# Altium schematic sheet PulserSampler.SchDoc(PulserSampler)
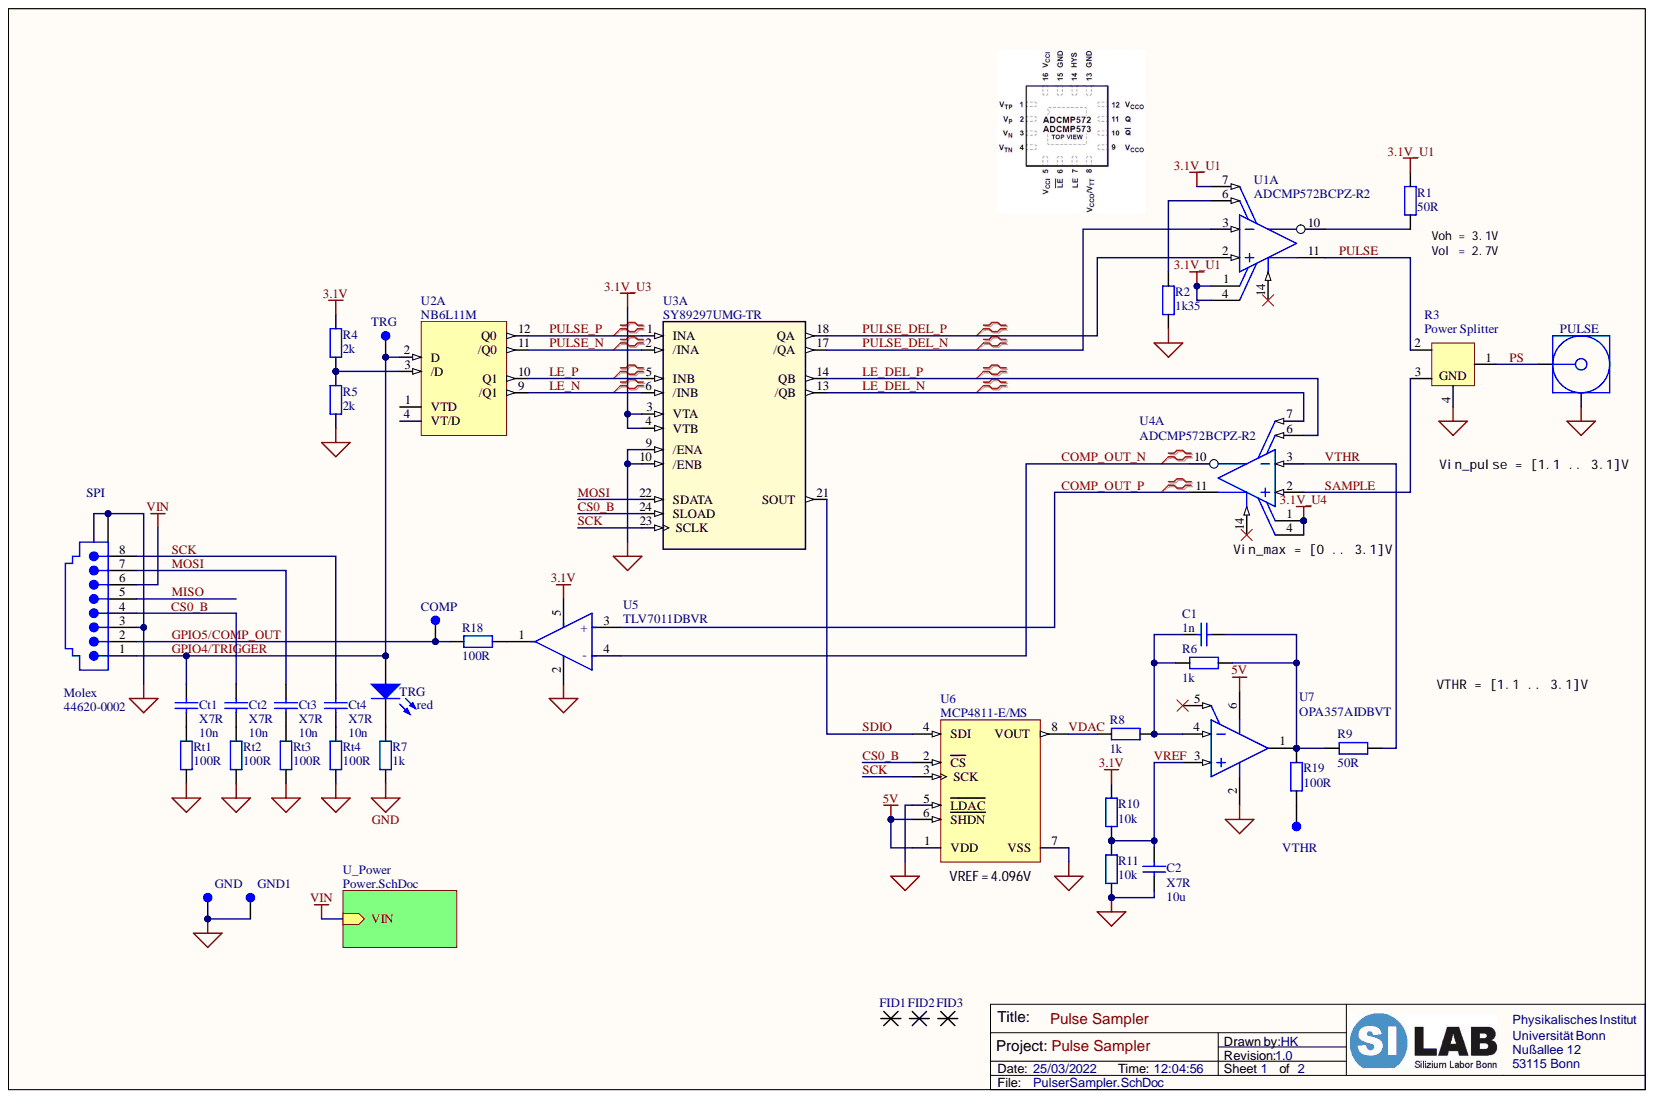
[diagram p0: PulserSampler.SchDoc]

## Parts
C1  value 1n  [C0603_imp_N]  (hidden comment: X7R)
C2  X7R  value 10u  [C0603_imp_N]
COMP  -  [Testpoint 1.0mm]  (value not drawn)
Ct1  X7R  value 10n  [C0603_imp_N]
Ct2  X7R  value 10n  [C0603_imp_N]
Ct3  X7R  value 10n  [C0603_imp_N]
Ct4  X7R  value 10n  [C0603_imp_N]
FID1  xxx  [Fiducial]  (value not drawn)
FID2  xxx  [Fiducial]  (value not drawn)
FID3  xxx  [Fiducial]  (value not drawn)
GND  -  [Testpoint 1.0mm]  (value not drawn)
GND1  -  [Testpoint 1.0mm]  (value not drawn)
PULSE  -  [SMA edge mount]  (value not drawn)
R1  R  value 50R  [R0402_imp_L]
R2  R  value 1k35  [R0402_imp_L]
R3  Power Splitter  [PS1608G]
R4  R  value 2k  [R0603_imp_N]
R5  R  value 2k  [R0603_imp_N]
R6  R  value 1k  [R0603_imp_N]
R7  R  value 1k  [R0603_imp_N]
R8  R  value 1k  [R0603_imp_N]
R9  R  value 50R  [R0402_imp_L]
R10  R  value 10k  [R0603_imp_N]
R11  R  value 10k  [R0603_imp_N]
R18  R  value 100R  [R0603_imp_N]
R19  R  value 100R  [R0402_imp_L]
Rt1  R  value 100R  [R0603_imp_N]
Rt2  R  value 100R  [R0603_imp_N]
Rt3  R  value 100R  [R0603_imp_N]
Rt4  R  value 100R  [R0603_imp_N]
SPI  Comment_1: Molex  [44620-0002]  (hidden comment: -)
TRG  red  [0603_A]
TRG  -  [Testpoint 1.0mm]  (value not drawn)
U1  ADCMP572BCPZ-R2  [ADI-CP-16-3_L]  (part A)
U2  NB6L11M  [QFN16 3x3]  (part A)
U3  SY89297UMG-TR  [QFN4X4-24]  (part A)
U4  ADCMP572BCPZ-R2  [ADI-CP-16-3_L]  (part A)
U5  TLV7011DBVR  [FP-DBV0005A-MFG]
U6  MCP4811-E/MS  [MSOP-MS8_L]
U7  OPA357AIDBVT  [DBV0006A_M]
VTHR  -  [Testpoint 1.0mm]  (value not drawn)

## Nets
3.1V: R4.1 R10.1 U5.5
3.1V_U1: R1.1 U1.1 U1.4 U1.7
3.1V_U3: U3.3(VTA) U3.4(VTB)
3.1V_U4: U4.1 U4.4
5V: U6.1(VDD) U6.6(SHDN) U7.6
GND: C2.2 GND.1 GND1.1 PULSE.2 R2.2 R3.4 R5.2 R7.2 R11.2 Rt1.1 Rt2.1 Rt3.1 Rt4.1 SPI.3 SPI.MH1 SPI.MH2 U3.9(/ENA) U3.10(/ENB) U5.2 U6.5(LDAC) U6.7(VSS) U7.2
VIN: SPI.6
COMP_OUT_N: U4.10 U5.4(-)
COMP_OUT_P: U4.11 U5.3(+)
CS0_B: Ct2.1 SPI.4 U3.24(SLOAD) U6.2(CS)
GPIO4/TRIGGER: Ct1.1 SPI.1 TRG.1 TRG.2 U2.2(D)
GPIO5/COMP_OUT: COMP.1 R18.2 SPI.2
LE_DEL_N: U3.13(/QB) U4.7
LE_DEL_P: U3.14(QB) U4.6
LE_N: U2.9(/Q1) U3.6(/INB)
LE_P: U2.10(Q1) U3.5(INB)
MISO: SPI.5
MOSI: Ct3.1 SPI.7 U3.22(SDATA)
PS: PULSE.1 R3.1
PULSE: R3.2 U1.11
PULSE_DEL_N: U1.3 U3.17(/QA)
PULSE_DEL_P: U1.2 U3.18(QA)
PULSE_N: U2.11(/Q0) U3.2(/INA)
PULSE_P: U2.12(Q0) U3.1(INA)
SAMPLE: R3.3(GND) U4.2
SCK: Ct4.1 SPI.8 U3.23(SCLK) U6.3(SCK)
SDIO: U3.21(SOUT) U6.4(SDI)
VDAC: R8.2 U6.8(VOUT)
VREF: C2.1 R10.2 R11.1 U7.3
VTHR: R9.2 U4.3
NetC1_1: C1.1 R6.2 R8.1 U7.4
NetC1_2: C1.2 R6.1 R9.1 R19.2 U7.1
NetCt1_2: Ct1.2 Rt1.2
NetCt2_2: Ct2.2 Rt2.2
NetCt3_2: Ct3.2 Rt3.2
NetCt4_2: Ct4.2 Rt4.2
NetR1_2: R1.2 U1.10
NetR2_1: R2.1 U1.6
NetR4_2: R4.2 R5.1 U2.3(/D)
NetR7_1: R7.1 TRG.1
NetR18_1: R18.1 U5.1
NetR19_1: R19.1 VTHR.1
NetU1_14: U1.14
NetU2_1: U2.1(VTD)
NetU2_4: U2.4(VT/D)
NetU4_14: U4.14
NetU7_5: U7.5

## Library data of the parts
C1 C2 Ct1-Ct4 = C: Capacitor; Class: Passive; Constraints: 6.3V; Type_1: SMD Chip Capacitor; Comment_1: -; Component Kind: Standard; HelpURL: -; LatestRevisionDate: 27-Nov-2007; PackageDescription: -; Signal Integrity: Capacitor; Simulation: Capacitor; Library Name: Silab_Lib.IntLib; Publisher: Silab J.S.
COMP GND GND1 TRG VTHR = Testpoint_gefüllt: Solderpad, Einzelner Kontakt; Class: Connector; Comment_1: -; Component Kind: Standard; Constraints: -; HelpURL: -; LatestRevisionDate: 24-Sep-2008; PackageDescription: -; Type_1: -; Value: -; Library Name: Silab_Lib.IntLib; Publisher: Silab C.H.
FID1-FID3 = Fiducial: Class: Passive; Comment_1: xxx; Component Kind: Standard; Constraints: xxx; Description: Fiducial; LatestRevisionDate: 09-Aug-2013; PackageDescription: xxx; Type_1: xxx; Value: xxx; HelpURL: http://www.   ...  or    X:\DXP\Datasheets\ ...; Library Name: Silab_Lib.IntLib; Publisher: Silab VF
PULSE = SMA: MCX RF Coaxial PCB Connector, Thru-Hole, Vertical Mount Socket, Blunt Post Terminal, 50 Ohm Impedance; Class: Connector; Comment_1: -; Component Kind: Standard; Constraints: -; HelpURL: http://www.amphenolrf.com/pdf/21.pdf; LatestRevisionDate: 24-Sep-2008; PackageDescription: -; Type_1: -; Value: -; Library Name: RPilabLib.IntLib; Publisher: Silab C.H.
R1 R2 R4-R11 R18 R19 Rt1-Rt4 = R: SMD Chip Resistor; Class: Passive; Constraints: 1%; Comment_1: -; Component Kind: Standard; HelpURL: -; LatestRevisionDate: 27-Nov-2007; PackageDescription: -; Signal Integrity: Resistor; Simulation: Resistor; Type_1: SMD Chip Resistor; Library Name: Silab_Lib.IntLib; Publisher: Silab J.S.
SPI = RJ-45 Buchse: Modular Jack, Right Angle RJ-45, Low Profile, Inverted, with all Panel Grounding Tabs, Shielded with RJ-11 Keepout Feature; Class: Connector; Component Kind: Standard; Constraints: -; HelpURL: http://www.molex.com/cmc_upload/common_files/1212003_mx44620.pdf; LatestRevisionDate: 24-Sep-2008; Signal Integrity: Modular Jack; Type_1: 44620-0002; Value: -; Library Name: Silab_Lib.IntLib; Publisher: Silab C.H.
TRG = CMP-1426-00001-1: SMD mono-color Chip LED, WL-SMCW, Red; Manufacturer: Wuerth Elektronik; PartNumber: 150060RS75000; Color: Red; Component Kind: Standard; ComponentLink1Description: Manufacturer URL; ComponentLink1URL: http://www.we-online.com; ComponentLink2Description: Datasheet; ComponentLink2URL: http://katalog.we-online.com/led/datasheet/150060RS75000.pdf; Library Name: Altium Content Vault; PackageReference: 0603_A
U1 U4 = CMP-0783-00022-1: Ultrafast Single-Supply SiGe Comparator, 3.3 to 5 V, 150 ps Delay, Industrial, 16-pin QFN (CP-16-3), Reel; Manufacturer: Analog Devices; Input Range (V): -0.5 to VCCI+0.5; Supply Current: 3.3, 5; Voltage Supply (V): 3.3 to 5; Code_JEDEC: MO-220-VEED-2; Component Kind: Standard; ComponentLink1Description: Manufacturer URL; ComponentLink1URL: http://www.analog.com/en/index.html; ComponentLink2Description: Datasheet; ComponentLink2URL: http://www.analog.com/static/imported-files/data_sheets/ADCMP572_573.pdf; ComponentLink3Description: Package Specification; ComponentLink3URL: http://www.analog.com/static/imported-files/packages/PKG_PDF/LFCSP(CP)/CP_16_3.pdf; ComponentLink4Description: URL Link1; ComponentLink4URL: Product URL:http://www.analog.com/en/special-linear-functions/comparators/adcmp572/products/product.html; DatasheetVersion: Rev. A; Library Name: Altium Content Vault; Mounting Technology: Surface Mount; PackageDescription: 16-Pin Lead Frame Chip Scale Package, Body 3 x 3 mm, Pitch 0.5 mm; PackageReference: CP-16-3; Packing: Reel; PartNumber: ADCMP572BCPZ-R2
U2 = NB6L11M: CML 1:2 fanout buffer; Component Kind: Standard; Library Name: RPiLab.SchLib
U3 = SY89297UMG-TR: No Description Available; Mfr_Name: Microchip; Component Kind: Standard; Library Name: RPiLab.SchLib; Manufacturer_Part_Number: SY89297UMG-TR; RefDes: RefDes; Type: DEV; Copyright: Copyright (C) 2022 Ultra Librarian. All rights reserved.
U5 = CMP-04896-000099-1: VOLTAGE COMPARATOR; Component Kind: Standard; Library Name: Altium Content Vault
U6 = CMP-0188-01332-1: 10-Bit DACs with Internal VREF and SPI Interface, 8-Pin MSOP, Extended Temperature; Component Kind: Standard; ComponentLink1Description: Manufacturer URL; ComponentLink1URL: http://www.microchip.com/; ComponentLink2Description: Datasheet; ComponentLink2URL: http://ww1.microchip.com/downloads/en/DeviceDoc/22244B.pdf; ComponentLink3Description: Package Specification; ComponentLink3URL: http://www.microchip.com/stellent/groups/techpub_sg/documents/packagingspec/en012702.pdf; DatasheetVersion: revB, Apr-2010; Library Name: Altium Content Vault; PackageDescription: 8-Lead Plastic Micro Small Outline Package (MS) [MSOP]; PackageReference: MSOP-MS8; PackageVersion: revBB, Aug-2009
U7 = CMP-0018-00468-2: 250 MHz, Rail-to-Rail I/O, Single CMOS Operational Amplifier with Shutdown, 2.5 to 5.5 V, -40 to 125 degC, 6-pin SOT23 (DBV6), Green (RoHS & no Sb/Br); Manufacturer: Texas Instruments; 2nd Harmonic(dBc): 75; 3rd Harmonic(dBc): 83; @ MHz: 1; BW @ Acl(MHz): 250; Diff Gain(%): 0.02; Diff Phase(deg): 0.09; Iib(Max)(nA): 0.05; Io(Typ)(mA): 100; Iq per channel(Max)(mA): 7.5; Rail-Rail: In, Out; Settling Time (0.1%)(Typ)(ns): 30; Slew Rate(Typ)(V/us): 150; Sub Family: High Output Current Amplifier (>=50mA), High Speed Amplifier (>=50MHz), Low Supply Voltage Amplifier (<=2.7V); THD (Fc is 1 MHz)(Typ)(dB): -74; Vio(Max)(mV): 8; Vn at Flatband(Typ)(nV/rtHz): 6.5; Vs(Max)(V): 5.5; Vs(Min)(V): 2.5; Acl, min stable gain(V/V): 1; Code_JEDEC: MO-178; Component Kind: Standard; ComponentLink1Description: Manufacturer URL; ComponentLink1URL: http://www.ti.com/; ComponentLink2Description: Datasheet; ComponentLink2URL: http://www.ti.com/general/docs/lit/getliterature.tsp?genericPartNumber=OPA357&fileType=pdf; ComponentLink3Description: Package Specification; ComponentLink3URL: http://www.ti.com/litv/pdf/mpds026h; DatasheetVersion: SBOS235E; Eco-Plan: Green (RoHS & no Sb/Br); Library Name: Altium Content Vault; Mounting Technology: Surface Mount; Number of Channels: 1; PackageDescription: 6-Pin Plastic Small Outline, Body 1.6 x 2.9 mm, Pitch 0.95 mm; PackageReference: DBV0006A; PackageVersion: 4073253-5/K, 03/2006; PartNumber: OPA357AIDBVT
R3 = Power Splitter: Component Kind: Standard; Library Name: RPilabLib.IntLib
